# Altium schematic sheet enginaator.SchDoc(enginaator)
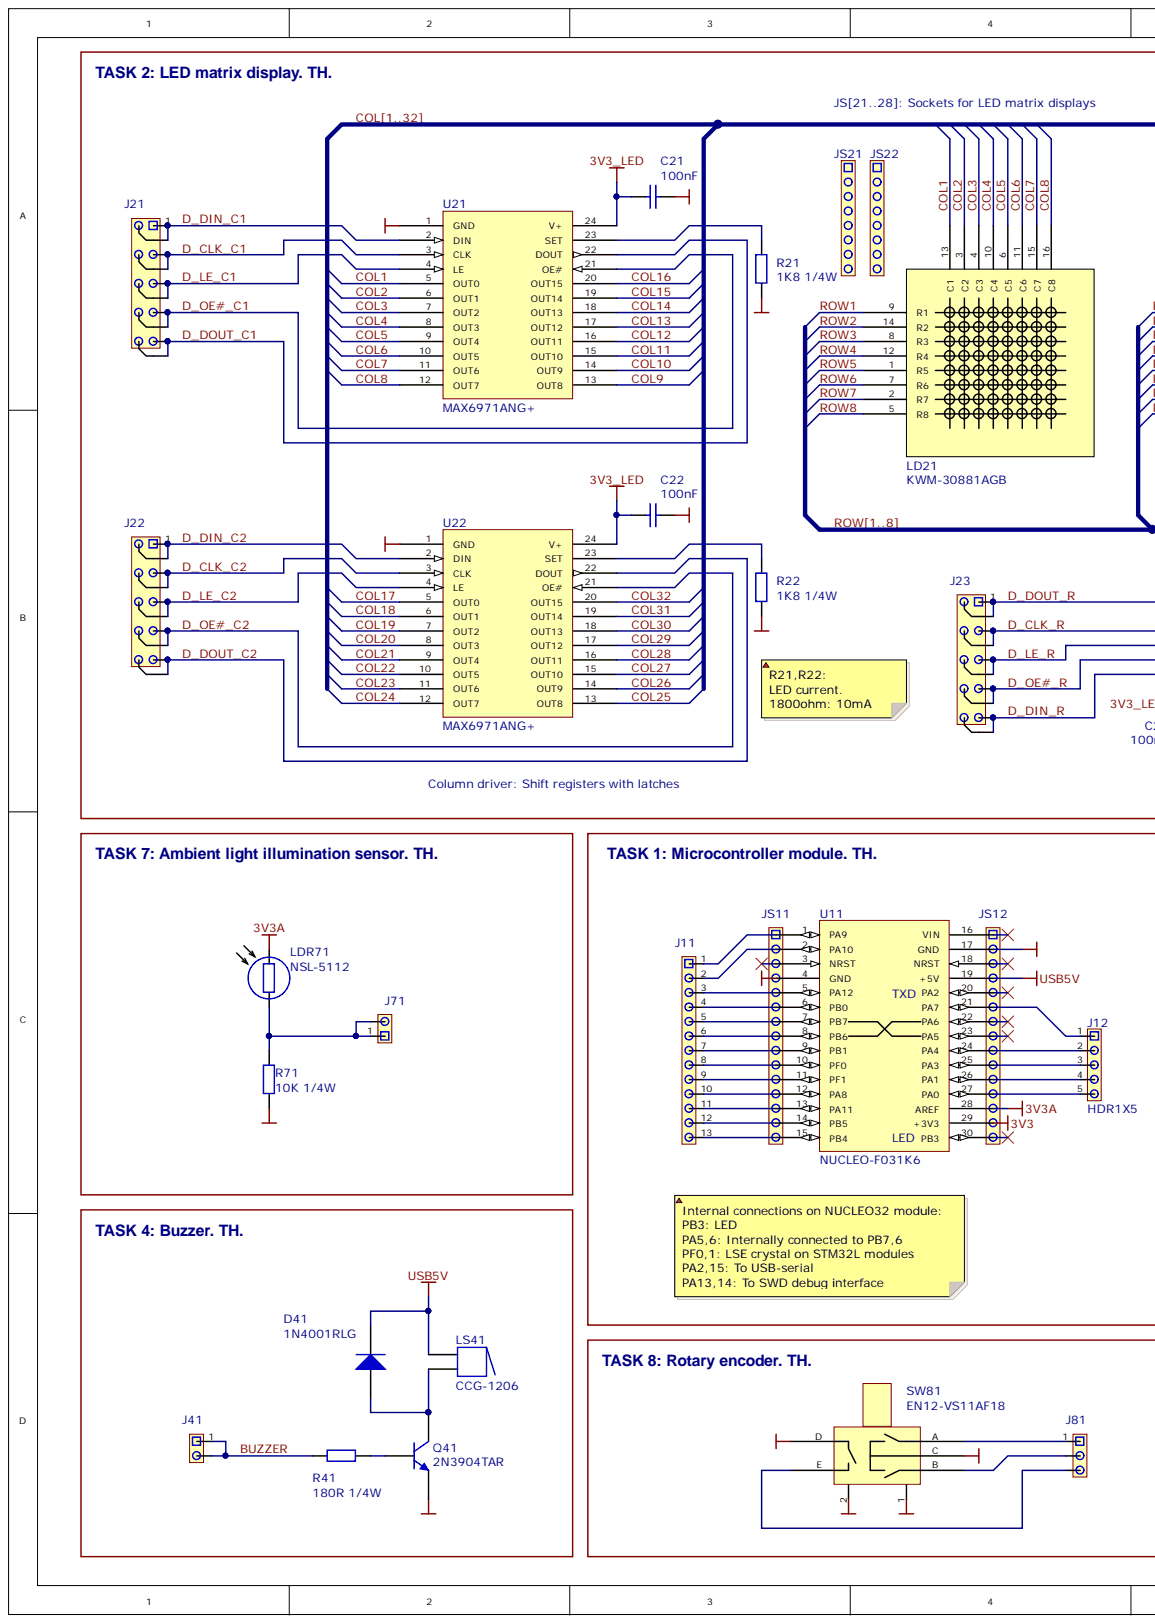
[diagram p0: enginaator.SchDoc, left]
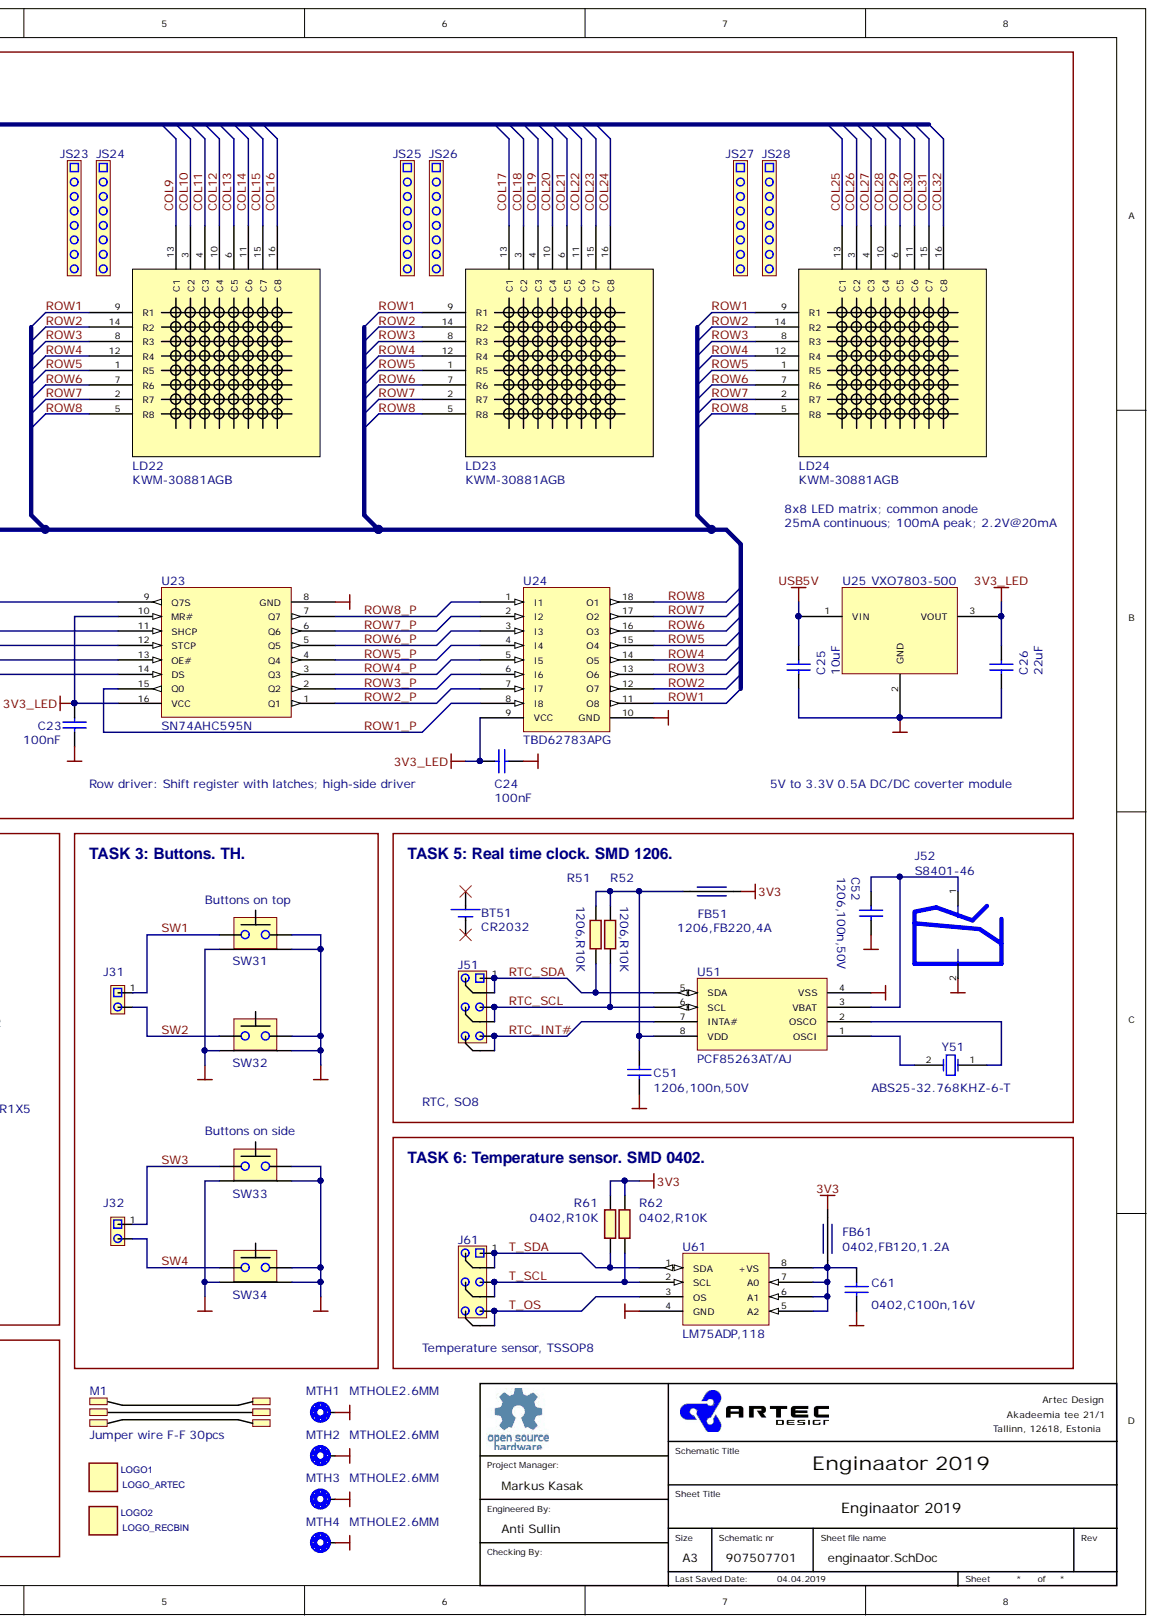
[diagram p1: enginaator.SchDoc, right]

## Parts
BT51  CR2032  (mechanical)
C21  100nF  [CAP_TH_RADIAL_5MM]
C22  100nF  [CAP_TH_RADIAL_5MM]
C23  100nF  [CAP_TH_RADIAL_5MM]
C24  100nF  [CAP_TH_RADIAL_5MM]
C25  10uF  [CAP_TH_RADIAL_5MM]
C26  22uF  [CAP_TH_RADIAL_5MM]
C51  1206,100n,50V  [C1206]
C52  1206,100n,50V  [C1206]
C61  0402,C100n,16V  [C0402]
D41  1N4001RLG  [DIODE_TH_4x2MM]
FB51  1206,FB220,4A  [L1206]
FB61  0402,FB120,1.2A  [L0402]
J11  HDR1X13  [HDRTHV254P_1X13]  (value not drawn)
J12  HDR1X5  [HDRTHV254P_1X5]
J21  HDR2X5  [HDRTHv254P_2X5]  (value not drawn)
J22  HDR2X5  [hdrthv254p_2x5]  (value not drawn)
J23  HDR2X5  [hdrthv254p_2x5]  (value not drawn)
J31  HDR1X2  [HDRTHV254P_1X2]  (value not drawn)
J32  HDR1X2  [HDRTHV254P_1X2]  (value not drawn)
J41  HDR1X2  [HDRTHV254P_1X2]  (value not drawn)
J51  HDR2X3  [HDRTHV254P_2X3]  (value not drawn)
J52  S8401-46  [S8401-46]
J61  HDR2X3  [HDRTHV254P_2X3]  (value not drawn)
J71  HDR1X2  [HDRTHV254P_1X2]  (value not drawn)
J81  HDR1X3  [HDRTHV254P_1X3]  (value not drawn)
JS11  SOCKET_1X15  [HDRTHV254P_1X15_MECH]  (value not drawn)
JS12  SOCKET_1X15  [HDRTHV254P_1X15_MECH]  (value not drawn)
JS21  SOCKET_1X8  [HDRTHV254P_1X8_MECH]  (value not drawn)
JS22  SOCKET_1X8  [HDRTHV254P_1X8_MECH]  (value not drawn)
JS23  SOCKET_1X8  [HDRTHV254P_1X8_MECH]  (value not drawn)
JS24  SOCKET_1X8  [HDRTHV254P_1X8_MECH]  (value not drawn)
JS25  SOCKET_1X8  [HDRTHV254P_1X8_MECH]  (value not drawn)
JS26  SOCKET_1X8  [HDRTHV254P_1X8_MECH]  (value not drawn)
JS27  SOCKET_1X8  [HDRTHV254P_1X8_MECH]  (value not drawn)
JS28  SOCKET_1X8  [HDRTHV254P_1X8_MECH]  (value not drawn)
LD21  KWM-30881AGB  [LED8x8_32x32mm]
LD22  KWM-30881AGB  [LED8x8_32x32mm]
LD23  KWM-30881AGB  [LED8x8_32x32mm]
LD24  KWM-30881AGB  [LED8x8_32x32mm]
LDR71  NSL-5112  [PHOTODIODE_4MM]
LOGO1  LOGO_ARTEC  [LOGO_ARTEC]  (no BOM)
LOGO2  LOGO_RECBIN  [LOGO_RECBIN]  (no BOM)
LS41  CCG-1206  [BUZZER_12MM]
M1  Jumper wire F-F 30pcs  (mechanical)
MTH1  MTHOLE2.6MM  [MTHOLE2.6MM]  (no BOM)
MTH2  MTHOLE2.6MM  [MTHOLE2.6MM]  (no BOM)
MTH3  MTHOLE2.6MM  [MTHOLE2.6MM]  (no BOM)
MTH4  MTHOLE2.6MM  [MTHOLE2.6MM]  (no BOM)
Q41  2N3904TAR  [TO-226-AA-WIDE]
R21  1K8 1/4W  [AXIAL-0.4]
R22  1K8 1/4W  [AXIAL-0.4]
R41  180R 1/4W  [AXIAL-0.4]
R51  1206,R10K  [R1206]
R52  1206,R10K  [R1206]
R61  0402,R10K  [R0402]
R62  0402,R10K  [R0402]
R71  10K 1/4W  [AXIAL-0.4]
SW31  EVQ-PF106K  [SW_6x6_RA]  (value not drawn)
SW32  EVQ-PF106K  [SW_6x6_RA]  (value not drawn)
SW33  EVQ-PF106K  [SW_6x6_RA]  (value not drawn)
SW34  EVQ-PF106K  [SW_6x6_RA]  (value not drawn)
SW81  EN12-VS11AF18  [ROT_ENC_RA_12x12]
U11  NUCLEO-F031K6
U21  MAX6971ANG+  [DIP24-Narrow]
U22  MAX6971ANG+  [DIP24-Narrow]
U23  SN74AHC595N  [dip16]
U24  TBD62783APG
U25  VXO7803-500  [VXO7803-500]
U51  PCF85263AT/AJ  [SO8_HANDSOLDER]
U61  LM75ADP,118  [SOT505-1_HANDSOLDER]
Y51  ABS25-32.768KHZ-6-T  [XTAL_8x3.8MM_HANDSOLDER]

## Nets
3V3: FB51.1 FB61.2 R61.2 R62.2 U11.29(+3V3)
3V3_LED: C21.2 C22.2 C23.2 C24.2 C26.1 U21.24(V+) U22.24(V+) U23.10(MR#) U23.16(VCC) U24.9(VCC) U25.3
3V3A: LDR71.2 U11.28(AREF)
GND: C21.1 C22.1 C23.1 C24.1 C25.2 C26.2 C51.2 C52.2 C61.2 J52.2 MTH1.1 MTH2.1 MTH3.1 MTH4.1 Q41.3 R21.2 R22.2 R71.1 SW31.1 SW31.2 SW31.A SW32.1 SW32.2 SW32.B SW33.1 SW33.2 SW33.A SW34.1 SW34.2 SW34.B SW81.1 SW81.2 SW81.C SW81.D U11.4(GND) U11.17(GND) U21.1(GND) U22.1(GND) U23.8(GND) U24.10(GND) U25.2 U51.4(VSS) U61.4(GND)
USB5V: C25.1 D41.2 LS41.1 U11.19(+5V) U25.1
BUZZER: J41.1 J41.2 R41.1
COL1: LD21.13(C1) U21.5(OUT0)  [also labelled COL]
COL2: LD21.3(C2) U21.6(OUT1)  [also labelled COL]
COL3: LD21.4(C3) U21.7(OUT2)  [also labelled COL]
COL4: LD21.10(C4) U21.8(OUT3)  [also labelled COL]
COL5: LD21.6(C5) U21.9(OUT4)  [also labelled COL]
COL6: LD21.11(C6) U21.10(OUT5)  [also labelled COL]
COL7: LD21.15(C7) U21.11(OUT6)  [also labelled COL]
COL8: LD21.16(C8) U21.12(OUT7)  [also labelled COL]
COL9: LD22.13(C1) U21.13(OUT8)  [also labelled COL]
COL10: LD22.3(C2) U21.14(OUT9)  [also labelled COL]
COL11: LD22.4(C3) U21.15(OUT10)  [also labelled COL]
COL12: LD22.10(C4) U21.16(OUT11)  [also labelled COL]
COL13: LD22.6(C5) U21.17(OUT12)  [also labelled COL]
COL14: LD22.11(C6) U21.18(OUT13)  [also labelled COL]
COL15: LD22.15(C7) U21.19(OUT14)  [also labelled COL]
COL16: LD22.16(C8) U21.20(OUT15)  [also labelled COL]
COL17: LD23.13(C1) U22.5(OUT0)  [also labelled COL]
COL18: LD23.3(C2) U22.6(OUT1)  [also labelled COL]
COL19: LD23.4(C3) U22.7(OUT2)  [also labelled COL]
COL20: LD23.10(C4) U22.8(OUT3)  [also labelled COL]
COL21: LD23.6(C5) U22.9(OUT4)  [also labelled COL]
COL22: LD23.11(C6) U22.10(OUT5)  [also labelled COL]
COL23: LD23.15(C7) U22.11(OUT6)  [also labelled COL]
COL24: LD23.16(C8) U22.12(OUT7)  [also labelled COL]
COL25: LD24.13(C1) U22.13(OUT8)  [also labelled COL]
COL26: LD24.3(C2) U22.14(OUT9)  [also labelled COL]
COL27: LD24.4(C3) U22.15(OUT10)  [also labelled COL]
COL28: LD24.10(C4) U22.16(OUT11)  [also labelled COL]
COL29: LD24.6(C5) U22.17(OUT12)  [also labelled COL]
COL30: LD24.11(C6) U22.18(OUT13)  [also labelled COL]
COL31: LD24.15(C7) U22.19(OUT14)  [also labelled COL]
COL32: LD24.16(C8) U22.20(OUT15)  [also labelled COL]
D_CLK_C1: J21.3 J21.4 U21.3(CLK)
D_CLK_C2: J22.3 J22.4 U22.3(CLK)
D_CLK_R: J23.3 J23.4 U23.11(SHCP)
D_DIN_C1: J21.1 J21.2 U21.2(DIN)
D_DIN_C2: J22.1 J22.2 U22.2(DIN)
D_DIN_R: J23.9 J23.10 U23.14(DS)
D_DOUT_C1: J21.9 J21.10 U21.22(DOUT)
D_DOUT_C2: J22.9 J22.10 U22.22(DOUT)
D_DOUT_R: J23.1 J23.2 U23.9(Q7S)
D_LE_C1: J21.5 J21.6 U21.4(LE)
D_LE_C2: J22.5 J22.6 U22.4(LE)
D_LE_R: J23.5 J23.6 U23.12(STCP)
D_OE#_C1: J21.7 J21.8 U21.21(OE#)
D_OE#_C2: J22.7 J22.8 U22.21(OE#)
D_OE#_R: J23.7 J23.8 U23.13(OE#)
ROW1: LD21.9(R1) LD22.9(R1) LD23.9(R1) LD24.9(R1) U24.11(O8)  [also labelled ROW]
ROW1_P: U23.15(Q0) U24.8(I8)
ROW2: LD21.14(R2) LD22.14(R2) LD23.14(R2) LD24.14(R2) U24.12(O7)  [also labelled ROW]
ROW2_P: U23.1(Q1) U24.7(I7)
ROW3: LD21.8(R3) LD22.8(R3) LD23.8(R3) LD24.8(R3) U24.13(O6)  [also labelled ROW]
ROW3_P: U23.2(Q2) U24.6(I6)
ROW4: LD21.12(R4) LD22.12(R4) LD23.12(R4) LD24.12(R4) U24.14(O5)  [also labelled ROW]
ROW4_P: U23.3(Q3) U24.5(I5)
ROW5: LD21.1(R5) LD22.1(R5) LD23.1(R5) LD24.1(R5) U24.15(O4)  [also labelled ROW]
ROW5_P: U23.4(Q4) U24.4(I4)
ROW6: LD21.7(R6) LD22.7(R6) LD23.7(R6) LD24.7(R6) U24.16(O3)  [also labelled ROW]
ROW6_P: U23.5(Q5) U24.3(I3)
ROW7: LD21.2(R7) LD22.2(R7) LD23.2(R7) LD24.2(R7) U24.17(O2)  [also labelled ROW]
ROW7_P: U23.6(Q6) U24.2(I2)
ROW8: LD21.5(R8) LD22.5(R8) LD23.5(R8) LD24.5(R8) U24.18(O1)  [also labelled ROW]
ROW8_P: U23.7(Q7) U24.1(I1)
RTC_INT#: J51.5 J51.6 U51.7(INTA#)
RTC_SCL: J51.3 J51.4 R52.1 U51.6(SCL)
RTC_SDA: J51.1 J51.2 R51.1 U51.5(SDA)
SW1: J31.1 SW31.B
SW2: J31.2 SW32.A
SW3: J32.1 SW33.B
SW4: J32.2 SW34.A
T_OS: J61.5 J61.6 U61.3(OS)
T_SCL: J61.3 J61.4 R62.1 U61.2(SCL)
T_SDA: J61.1 J61.2 R61.1 U61.1(SDA)
NetC51_1: C51.1 FB51.2 R51.2 R52.2 U51.8(VDD)
NetC52_1: C52.1 J52.1 U51.3(VBAT)
NetC61_1: C61.1 FB61.1 U61.5(A2) U61.6(A1) U61.7(A0) U61.8(+VS)
NetD41_1: D41.1 LS41.2 Q41.1
NetJ11_1: J11.1 U11.1(PA9)
NetJ11_2: J11.2 U11.2(PA10)
NetJ11_3: J11.3 U11.5(PA12)
NetJ11_4: J11.4 U11.6(PB0)
NetJ11_5: J11.5 U11.7(PB7)
NetJ11_6: J11.6 U11.8(PB6)
NetJ11_7: J11.7 U11.9(PB1)
NetJ11_8: J11.8 U11.10(PF0)
NetJ11_9: J11.9 U11.11(PF1)
NetJ11_10: J11.10 U11.12(PA8)
NetJ11_11: J11.11 U11.13(PA11)
NetJ11_12: J11.12 U11.14(PB5)
NetJ11_13: J11.13 U11.15(PB4)
NetJ12_1: J12.1 U11.21(PA7)
NetJ12_2: J12.2 U11.24(PA4)
NetJ12_3: J12.3 U11.25(PA3)
NetJ12_4: J12.4 U11.26(PA1)
NetJ12_5: J12.5 U11.27(PA0)
NetJ71_1: J71.1 J71.2 LDR71.1 R71.2
NetJ81_1: J81.1 SW81.A
NetJ81_2: J81.2 SW81.B
NetJ81_3: J81.3 SW81.E
NetQ41_2: Q41.2 R41.2
NetR21_1: R21.1 U21.23(SET)
NetR22_1: R22.1 U22.23(SET)
NetU51_1: U51.1(OSCI) Y51.2
NetU51_2: U51.2(OSCO) Y51.1

Not connected: BT51 1 2; U11 3(NRST) 16(VIN) 18(NRST) 20(PA2) 22(PA6) 23(PA5) 30(PB3)

## Library data of the parts
BT51 = BAT: Part Type: CR2032; Library Name: enginaator.SchLib
C21-C24 = CAP_RADIAL_5MM: CAP 0.1UF 10% 63VDC RADIAL 5.0mm; Manufacturer: AVX Corporation; Value: 100nF,radial,5mm; Supplier1Item: 478-10736-2-ND; Supplier1: DIGI; Part Type: SR215E104MARTR2; Component Kind: Standard; Library Name: enginaator.SchLib
C25 = CAP_RADIAL_5MM: Manufacturer: TDK Corporation; Value: 10uF,radial,5mm; Supplier1Item: 445-181284-ND; Supplier1: DIGI; Part Type: FG28X5R1E106MRT06; Component Kind: Standard; Library Name: enginaator.SchLib
C26 = CAP_RADIAL_5MM: Manufacturer: TDK Corporation; Value: 22uF,radial,5mm; Supplier1Item: 445-173294-1-ND; Supplier1: DIGI; Part Type: FG20X7R1C226MRT06; Component Kind: Standard; Library Name: enginaator.SchLib
C51 C52 = 1206,100n,50V: 1206, C100n, 50V, +/-10%; Manufacturer: Yageo; Properties: 50V +/-10%; Database Table Name: capacitors; ADGPN: 2021210400; Supplier1item: 311-1179-1-ND; Supplier1: DIGI; Part Type: CC1206KRX7R9BB104; Library Name: AltiumSVNDatabase64.SVNDbLib; Component Kind: Standard
C61 = 0402,C100n,16V: 0402, C100n, 16V, +/-10%, X7R; Manufacturer: Murata; Properties: 16V, +/10%, X7R; Database Table Name: capacitors; ADGPN: R2001040600; Supplier1item: GRM155R71C104KA88D; Supplier1: JHEL; Part Type: GRM155R71C104KA88D; Library Name: AltiumSVNDatabase64.SVNDbLib; Component Kind: Standard
D41 = Diode: Default Diode; Manufacturer: ON Semiconductor; Supplier1Item: 1N4001RLGOSCT-ND; Supplier1: DIGI; Part Type: 1N4001RLG; Library Name: Miscellaneous Devices.IntLib; Component Kind: Standard
FB51 = 1206,FB220,4A: 1206, FB220, 4A, 20mOhm, FBMH3216HM221NT; Manufacturer: Taiyo Yuden; Properties: 220Ohm, DC 20mOhm; Database Table Name: inductors; ADGPN: 4901022010; Supplier1item: 587-3250-1-ND; Supplier1: DIGI; Part Type: FBMH3216HM221NT; Library Name: AltiumSVNDatabase64.SVNDbLib; HelpURL: http://ds.yuden.co.jp/TYCOMPAS/ut/detail.do?productNo=FBMH3216HM221NT&fileName=FBMH3216HM221NT_SS&mode=specSheetDownload; Component Kind: Standard
FB61 = 0402,FB120,1.2A: 0402, FB120,1200mA; Manufacturer: TDK; Properties: Ferr Chip Beads 120 Om 1200mA; Database Table Name: inductors; ADGPN: 4901012020; Supplier1item: 445-2981; Supplier1: DIGI; Part Type: MPZ1005S121C; Library Name: AltiumSVNDatabase64.SVNDbLib; Component Kind: Standard
J11 = HDR1X13: Manufacturer: CONNFLY; Supplier1Item: ZL201-40G; Supplier1: TME; Part Type: DS1021-1*40SF11; Component Kind: Standard; Library Name: enginaator.SchLib
J21 = HDR2X5: Manufacturer: CONNFLY; Supplier1Item: ZL202-80G; Supplier1: TME; Part Type: DS1021-2*40SF11; Component Kind: Standard; Library Name: enginaator.SchLib
J52 = S8401-46: S8401-46 Battery Holder, Vertical, H=16.9mm,  20mm coin, CR2032, -40+105C, TH, Harwin; Database Table Name: components; ADGPN: 4220034600; Properties: Battery Holder, Vertical, H=16.9mm,  20mm coin, CR2032, -40+105C, TH; Part Type: S8401-46; Manufacturer: Harwin; Library Name: AltiumSVNDatabase64.SVNDbLib; HelpURL: http://www.harwin.com/products/S8401-46/; Component Kind: Standard
JS11 JS12 = HDR1X15_MECH: Manufacturer: NINIGI; Supplier1Item: ZL305-15; Supplier1: TME; Part Type: ZL305-15; Component Kind: Standard; Library Name: enginaator.SchLib
JS21-JS28 = HDR1X8_MECH: Manufacturer: CONNFLY; Supplier1Item: DS1002-01-1X08V13; Supplier1: TME; Part Type: DS1002-01-1*8V13; Component Kind: Standard; Library Name: enginaator.SchLib
LD21-LD24 = LED_MATRIX_8x8: Manufacturer: LUCKY LIGHT; Supplier1Item: KWM-30881AGB; Supplier1: TME; Part Type: KWM-30881AGB; Component Kind: Standard; Library Name: enginaator.SchLib
LDR71 = PHOTORES: Manufacturer: Advanced Photonix; Supplier1Item: NSL-5112-ND; Supplier1: DIGI; Part Type: NSL-5112; Component Kind: Standard; Library Name: enginaator.SchLib
LOGO1 LOGO2 = BOM_only: Library Name: AltiumSVNDatabase64.SVNDbLib
LS41 = Buzzer: Magnetic Transducer Buzzer; Manufacturer: CUI Inc.; Supplier1Item: 102-1269-ND; Supplier1: DIGI; Part Type: CCG-1206; Library Name: Miscellaneous Devices.IntLib; Component Kind: Standard
M1 = JUMPERWIRE: Manufacturer: Adafruit Industries LLC; Supplier1Item: 1.5x 1528-1961-ND; Supplier1: DIGI; Part Type: 1950; Library Name: enginaator.SchLib
MTH1-MTH4 = MTHOLE2.6MM: MTHOLE2.6MM, M2.5 Mounting hole 2.9mm, plated; Manufacturer: NA; Properties: Mounting hole 2.6mm, M2.5, tight fit, plated; Database Table Name: connectors; ADGPN: 2699002600; Part Type: MTHOLE2.6MM; Library Name: AltiumSVNDatabase64.SVNDbLib
Q41 = NPN: NPN Bipolar Transistor; Manufacturer: ON Semi; Supplier1Item: 2N3904TARCT-ND; Supplier1: DIGI; Part Type: 2N3904TAR; Library Name: Miscellaneous Devices.IntLib; Component Kind: Standard
R21 R22 R71 = Res2: RES 1.8K OHM 1/4W 5% AXIAL; Manufacturer: Yageo; Supplier1: DIGI; Simulation: RESISTOR; Signal Integrity: Res; Library Name: Miscellaneous Devices.IntLib; Component Kind: Standard
  R21 R22: Value: 1K8 1/4W; Supplier1Item: 1.8KQBK-ND; Part Type: CFR-25JB-52-1K8
  R71: Value: 10K 1/4W; Supplier1Item: 10KQBK-ND; Part Type: CFR-25JB-52-10K
R41 = Res2: Resistor; Manufacturer: Yageo; Value: 180R 1/4W; Supplier1Item: 180QBK-ND; Supplier1: DIGI; Simulation: RESISTOR; Signal Integrity: Res; Part Type: CFR-25JB-52-180R; Library Name: Miscellaneous Devices.IntLib; Component Kind: Standard
R51 R52 = 1206,R10K: 1206, R10K, 1/4W, 1%; Manufacturer: Yageo; Database Table Name: resistors; ADGPN: 7526100240; Supplier1item: 311-10.0KFRCT-ND; Supplier1: DIGI; Properties: 1/4W, 1%; Part Type: RC1206FR-0710KL; Library Name: AltiumSVNDatabase64.SVNDbLib; Component Kind: Standard
R61 R62 = 0402,R10K: 0402, R10K, 1/16W, 1%, RC0402FR-0710KL, Yageo; Properties: 1%,1/16W, 50V; Database Table Name: resistors; ADGPN: 7542100200; Supplier1item: P10.0KLTR; Supplier1: DIGI; Part Type: RC0402FR-0710KL; Manufacturer: Yageo; Library Name: AltiumSVNDatabase64.SVNDbLib; Component Kind: Standard
SW31-SW34 = (no symbol name): Manufacturer: Panasonic Electronic Components; Supplier1item: P10883S-ND; Supplier1: DIGI; Part Type: EVQ-PF106K; Component Kind: Standard; Library Name: enginaator.SchLib
SW81 = ROT_ENC_SW: Manufacturer: TT Electronics/BI; Supplier1Item: 987-1198-ND; Supplier1: DIGI; Part Type: EN12-VS11AF18; Component Kind: Standard; Library Name: enginaator.SchLib
U11 = NUCLEO32: Manufacturer: STMicroelectronics; Supplier1Item: 497-15979-ND; Supplier1: DIGI; Part Type: NUCLEO-F031K6; Component Kind: Standard; Library Name: enginaator.SchLib
U21 U22 = MAX6971: Manufacturer: Maxim; Supplier1Item: MAX6971ANG+-ND; Supplier1: DIGI; Part Type: MAX6971ANG+; Component Kind: Standard; Library Name: enginaator.SchLib
U23 = 74HCT595: Manufacturer: TI; Supplier1Item: 296-4619-5-ND; Supplier1: DIGI; Part Type: SN74AHC595N; Component Kind: Standard; Library Name: enginaator.SchLib
U24 = TBD62xxx: Manufacturer: Toshiba Semiconductor and Storage; Supplier1Item: TBD62783APG-ND; Supplier1: DIGI; Part Type: TBD62783APG; Component Kind: Standard; Library Name: enginaator.SchLib
U25 = VXO78-500: Manufacturer: CUI Inc.; Supplier1Item: 102-4248-ND; Supplier1: DIGI; Part Type: VXO7803-500; Component Kind: Standard; Library Name: enginaator.SchLib
U51 = PCF85263AT: Manufacturer: NXP; Properties: RTC, SO8; Supplier1Item: 568-12405-1-ND; Supplier1: DIGI; Part Type: PCF85263AT/AJ; Component Kind: Standard; Library Name: enginaator.SchLib
U61 = LM75A: SENSOR DIGITAL -55C-125C 8TSSOP; Manufacturer: NXP; Properties: Temperature sensor, TSSOP8; Supplier1Item: 568-4227-1-ND; Supplier1: DIGI; Part Type: LM75ADP,118; Component Kind: Standard; Library Name: enginaator.SchLib
Y51 = XTAL: Crystal Oscillator; Manufacturer: Abracon; Supplier1Item: 535-10240-1-ND; Supplier1: DIGI; Part Type: ABS25-32.768KHZ-6-T; Library Name: Miscellaneous Devices.IntLib; Component Kind: Standard
J12 = HDR1X5: Part Type: part of J11; Component Kind: Standard; Library Name: enginaator.SchLib
J22 J23 = HDR2X5: Part Type: part of J21; Component Kind: Standard; Library Name: enginaator.SchLib
J31 J32 J41 J71 = HDR1X2: Part Type: part of J11; Component Kind: Standard; Library Name: enginaator.SchLib
J51 J61 = HDR2X3: Part Type: part of J21; Component Kind: Standard; Library Name: enginaator.SchLib
J81 = HDR1X3: Part Type: part of J11; Component Kind: Standard; Library Name: enginaator.SchLib
